# Altium schematic sheet MCU045A_eZFET_DCDC.SchDoc(MCU045A_eZFET_DCDC)
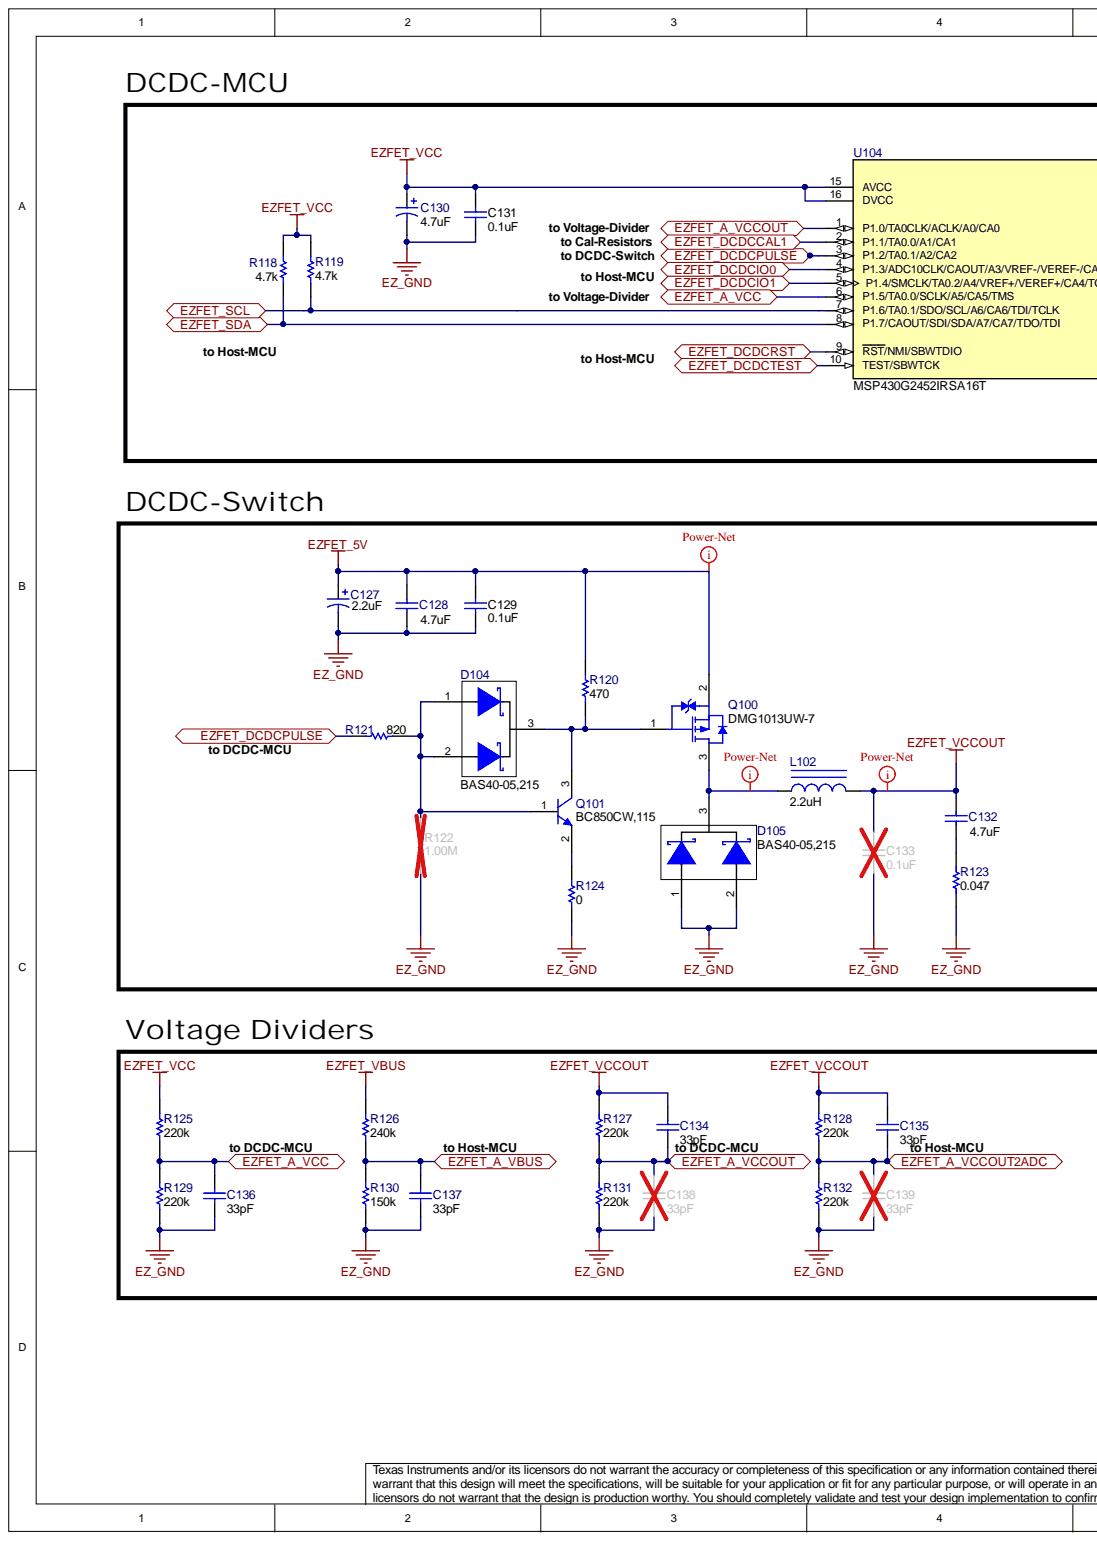
[diagram p0: MCU045A_eZFET_DCDC.SchDoc, left]
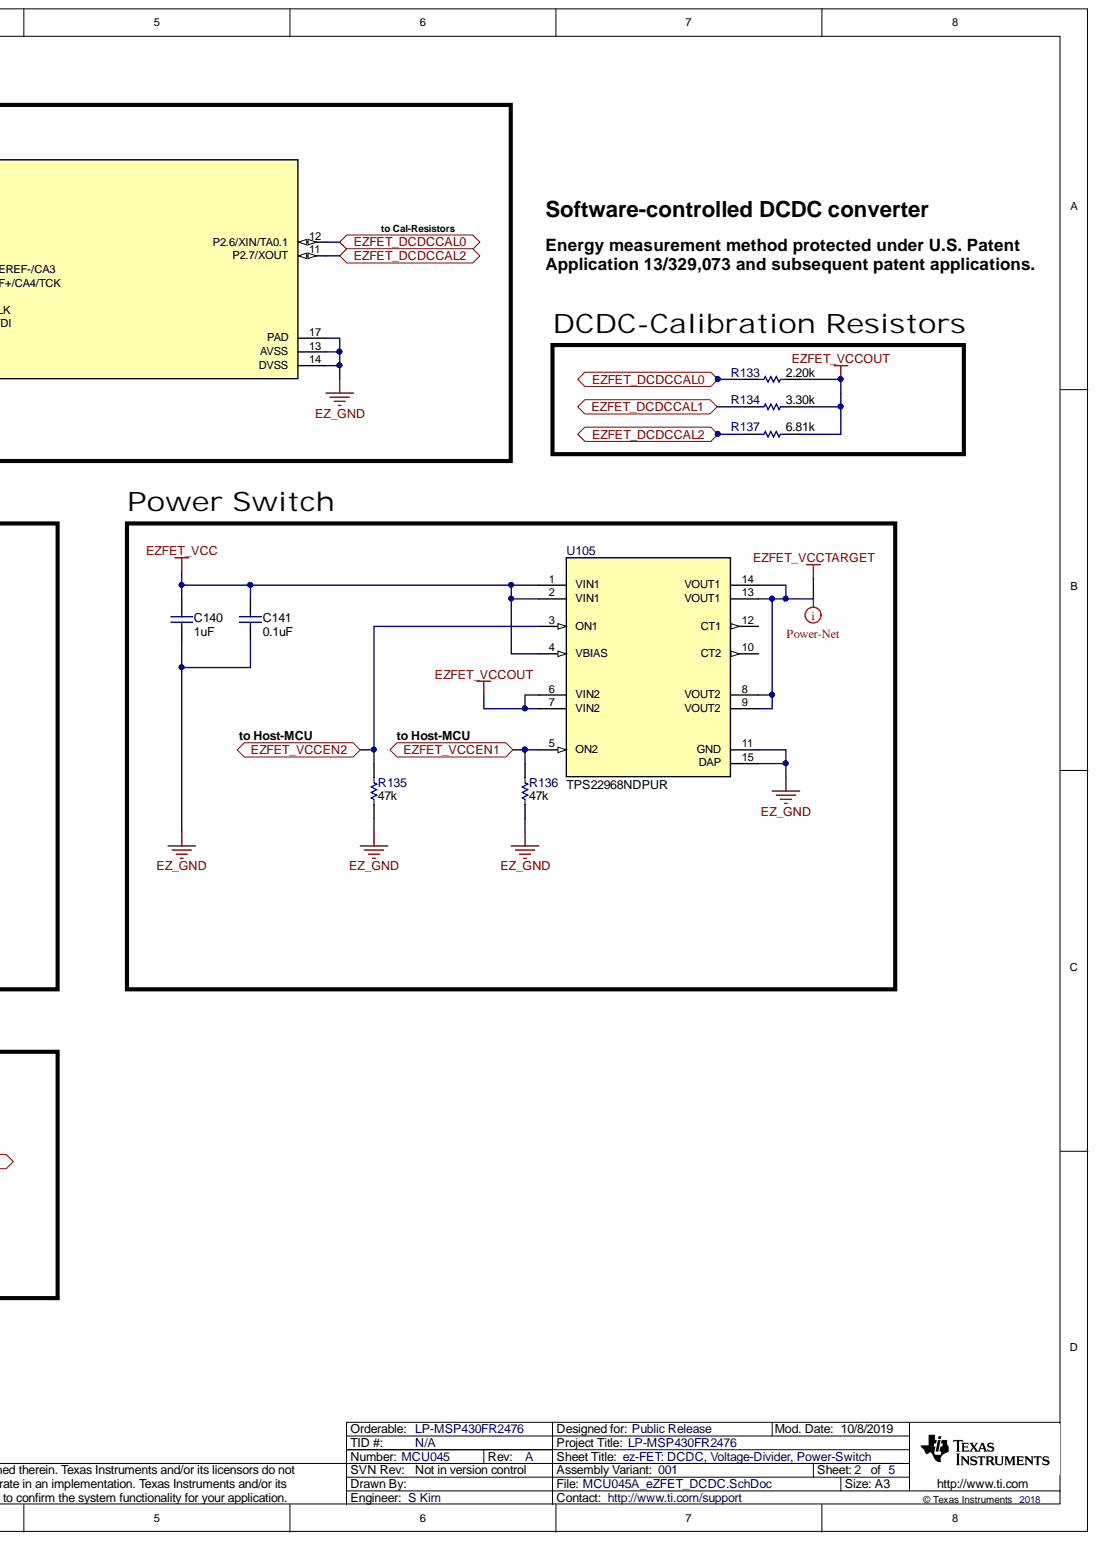
[diagram p1: MCU045A_eZFET_DCDC.SchDoc, right]

## Parts
C127  value 2.2uF  ValueDisplayed: 2.2uF  [3216-18]  (hidden comment: 293D225X9025A2TE3)
C128  value 4.7uF  ValueDisplayed: 4.7uF  [0805_HV]  (hidden comment: C0805C475K8PACTU)
C129  value 0.1uF  ValueDisplayed: 0.1uF  [0402]  (hidden comment: GCM155R71C104KA55D)
C130  value 4.7uF  ValueDisplayed: 4.7uF  [3216-18]  (hidden comment: 293D475X9010A2TE3)
C131  value 0.1uF  ValueDisplayed: 0.1uF  [0402]  (hidden comment: GCM155R71C104KA55D)
C132  value 4.7uF  ValueDisplayed: 4.7uF  [0603]  (hidden comment: CGB3B1X5R1A475K055AC)
C133  value 0.1uF  ValueDisplayed: 0.1uF  [0402]  (hidden comment: GMK105BJ104KV-F)
C134  value 33pF  ValueDisplayed: 33pF  [0402]  (hidden comment: 885012005043)
C135  value 33pF  ValueDisplayed: 33pF  [0402]  (hidden comment: 885012005043)
C136  value 33pF  ValueDisplayed: 33pF  [0402]  (hidden comment: 885012005043)
C137  value 33pF  ValueDisplayed: 33pF  [0402]  (hidden comment: 885012005043)
C138  value 33pF  ValueDisplayed: 33pF  [0402]  (hidden comment: 885012005043)
C139  value 33pF  ValueDisplayed: 33pF  [0402]  (hidden comment: 885012005043)
C140  value 1uF  ValueDisplayed: 1uF  [0402]  (hidden comment: GRM152R60J105ME15D)
C141  value 0.1uF  ValueDisplayed: 0.1uF  [0402]  (hidden comment: GCM155R71C104KA55D)
D104  BAS40-05,215  [SOT-23]
D105  BAS40-05,215  [SOT-23]
L102  value 2.2uH  ValueDisplayed: 2.2uH  [CBC2518]  (hidden comment: CBC2518T2R2M)
Q100  DMG1013UW-7  [SOT-323]
Q101  BC850CW,115  AEC Category: Q101  [SOT-323]
R118  value 4.7k  ValueDisplayed: 4.7k  [0402]  (hidden comment: CRCW04024K70JNED)
R119  value 4.7k  ValueDisplayed: 4.7k  [0402]  (hidden comment: CRCW04024K70JNED)
R120  value 470  [0402]  (hidden comment: RC0402FR-07470RL)
R121  value 820  [0402]  (hidden comment: RC0402FR-07820RL)
R122  ValueDisplayed: 1.00M  [0402]  (hidden comment: CRCW04021M00FKED)
R123  value 0.047  ValueDisplayed: 0.047  [0603]  (hidden comment: ERJ-L03KF47MV)
R124  value 0  [0402]  (hidden comment: RC0402JR-070RL)
R125  value 220k  ValueDisplayed: 220k  [0402]  (hidden comment: RC0402FR-07220KL)
R126  value 240k  ValueDisplayed: 240k  [0402]  (hidden comment: CRCW0402240KFKED)
R127  value 220k  ValueDisplayed: 220k  [0603]  (hidden comment: CPF0603B220KE)
R128  value 220k  ValueDisplayed: 220k  [0603]  (hidden comment: CPF0603B220KE)
R129  value 220k  ValueDisplayed: 220k  [0402]  (hidden comment: RC0402FR-07220KL)
R130  value 150k  ValueDisplayed: 150k  [0402]  (hidden comment: CRCW0402150KFKED)
R131  value 220k  ValueDisplayed: 220k  [0603]  (hidden comment: CPF0603B220KE)
R132  value 220k  ValueDisplayed: 220k  [0603]  (hidden comment: CPF0603B220KE)
R133  value 2.20k  ValueDisplayed: 2.20k  [0402]  (hidden comment: ERA2AEB222X)
R134  value 3.30k  ValueDisplayed: 3.30k  [0402]  (hidden comment: ERA2ARB332X)
R135  value 47k  ValueDisplayed: 47k  [0402]  (hidden comment: CRCW040247K0JNED)
R136  value 47k  ValueDisplayed: 47k  [0402]  (hidden comment: CRCW040247K0JNED)
R137  value 6.81k  ValueDisplayed: 6.81k  [0402]  (hidden comment: ERA2AEB6811X)
U104  MSP430G2452IRSA16T  [RSA0016B]
U105  TPS22968NDPUR  [DPU0014A]

## Ports
EZFET_A_VBUS, EZFET_A_VCC, EZFET_A_VCCOUT, EZFET_A_VCCOUT2ADC, EZFET_DCDCCAL0, EZFET_DCDCCAL1, EZFET_DCDCCAL2, EZFET_DCDCIO0, EZFET_DCDCIO1, EZFET_DCDCPULSE, EZFET_DCDCRST, EZFET_DCDCTEST, EZFET_SCL, EZFET_SDA, EZFET_VCCEN1, EZFET_VCCEN2

## Nets
EZ_GND: C127.2 C128.2 C129.2 C130.2 C131.2 C133.2 C136.2 C137.2 C138.2 C139.2 C140.2 C141.1 D105.1 D105.2 R122.1 R123.1 R124.1 R129.1 R130.1 R131.1 R132.1 R135.1 R136.1 U104.13(AVSS) U104.14(DVSS) U104.17(PAD) U105.11(GND) U105.15(DAP)
EZFET_5V: C127.1 C128.1 C129.1 Q100.2 R120.2
EZFET_VBUS: R126.2
EZFET_VCC: C130.1 C131.1 C140.1 C141.2 R118.2 R119.2 R125.2 U104.15(AVCC) U104.16(DVCC) U105.1(VIN1) U105.2(VIN1) U105.4(VBIAS)
EZFET_VCCOUT: C132.1 C133.1 C134.1 C135.1 L102.2 R127.2 R128.2 R133.2 R134.2 R137.2 U105.6(VIN2) U105.7(VIN2)
EZFET_VCCTARGET: U105.8(VOUT2) U105.9(VOUT2) U105.13(VOUT1) U105.14(VOUT1)
EZFET_A_VBUS: C137.1 R126.1 R130.2 ; port EZFET_A_VBUS
EZFET_A_VCC: C136.1 R125.1 R129.2 U104.6(P1.5/TA0.0/SCLK/A5/CA5/TMS) ; port EZFET_A_VCC
EZFET_A_VCCOUT: C134.2 C138.1 R127.1 R131.2 U104.1(P1.0/TA0CLK/ACLK/A0/CA0) ; port EZFET_A_VCCOUT
EZFET_A_VCCOUT2ADC: C135.2 C139.1 R128.1 R132.2 ; port EZFET_A_VCCOUT2ADC
EZFET_DCDCCAL0: R133.1 U104.12(P2.6/XIN/TA0.1) ; port EZFET_DCDCCAL0
EZFET_DCDCCAL1: R134.1 U104.2(P1.1/TA0.0/A1/CA1) ; port EZFET_DCDCCAL1
EZFET_DCDCCAL2: R137.1 U104.11(P2.7/XOUT) ; port EZFET_DCDCCAL2
EZFET_DCDCIO0: U104.4(P1.3/ADC10CLK/CAOUT/A3/VREF-/VEREF-/CA3) ; port EZFET_DCDCIO0
EZFET_DCDCIO1: U104.5(P1.4/SMCLK/TA0.2/A4/VREF+/VEREF+/CA4/TCK) ; port EZFET_DCDCIO1
EZFET_DCDCPULSE: R121.1 U104.3(P1.2/TA0.1/A2/CA2) ; port EZFET_DCDCPULSE
EZFET_DCDCRST: U104.9(RST/NMI/SBWTDIO) ; port EZFET_DCDCRST
EZFET_DCDCTEST: U104.10(TEST/SBWTCK) ; port EZFET_DCDCTEST
EZFET_SCL: R119.1 U104.7(P1.6/TA0.1/SDO/SCL/A6/CA6/TDI/TCLK) ; port EZFET_SCL
EZFET_SDA: R118.1 U104.8(P1.7/CAOUT/SDI/SDA/A7/CA7/TDO/TDI) ; port EZFET_SDA
EZFET_VCCEN1: R136.2 U105.5(ON2) ; port EZFET_VCCEN1
EZFET_VCCEN2: R135.2 U105.3(ON1) ; port EZFET_VCCEN2
NetC132_2: C132.2 R123.2
NetD104_1: D104.1 D104.2 Q101.1 R121.2 R122.2
NetD104_3: D104.3 Q100.1 Q101.3 R120.1
NetD105_3: D105.3 L102.1 Q100.3
NetQ101_2: Q101.2 R124.2

Not connected: U105 10(CT2) 12(CT1)

## Library data of the parts
C127 = CMP-0011454-2: CAP, TA, 2.2 uF, 25 V, +/- 10%, 6.3 ohm, SMD; Manufacturer: Vishay-Sprague; Value2: 25V; Value1: 6.3 ohm; U/M: EA; TaMin_C: -55°C; TaMax_C: 125°C; SizeZ_mm: 1.8; SizeY_mm: 1.6; SizeX_mm: 3.2; SizeAreaWB_mm2: 10.92; SizeArea_mm2: 5.12; Polarized: Y; IRMS_A: 1.1dA; ESR_ohm: 6.3; CompType: Capacitor; Cap_F: 2.2µF; Cap_Case: A; AEC Category: Q200; Tolerance_%: 10%; Technology: TA; Supplier Part Number 3: 74-293D225X9025A2TE3; Supplier Part Number 2: 09K6176; Supplier Part Number 1: 718-1134-1-ND; Supplier 3: Mouser; Supplier 2: Newark; Supplier 1: Digi-Key; Series: 293D; RoHS: Y; PartNumber: 293D225X9025A2TE3; PackageReference: 3216-18; MountType: SMT; Library Name: Altium Vault - TI; LatestRevisionNote: Updated supplier links; LatestRevisionDate: 2017-03-09; ComponentLink1URL: http://www.vishay.com/docs/40002/293d.pdf; ComponentLink1Description: Datasheet link; Component Kind: Standard; AEC Qualified: ?; Publisher: Texas Instruments
C128 = CMP-0007801-2: CAP, CERM, 4.7 uF, 10 V, +/- 10%, X5R, 0805; Manufacturer: Kemet; VDC_V: 10V; Value1: 0.004 ohm; U/M: EA; TaMin_C: -55°C; TaMax_C: 85°C; SizeZ_mm: 1.45; SizeY_mm: 1.25; SizeX_mm: 2; SizeAreaWB_mm2: 6.75; SizeArea_mm2: 2.5; Polarized: N; IRMS_A: 9.89A; ESR_ohm: 4m; CompType: Capacitor; Cap_F: 4.7µF; Cap_Case: 0805; AEC Category: Q200; Value2: 10V; Tolerance_%: 10%; TempCo: X5R; Technology: Ceramic; Supplier Part Number 3: 80-C0805C475K8P; Supplier Part Number 2: 70K9144; Supplier Part Number 1: 399-3133-1-ND; Supplier 3: Mouser; Supplier 2: Newark; Supplier 1: Digi-Key; RoHS: Y; Published: 2008-06-19; PartNumber: C0805C475K8PACTU; PackageReference: 0805; MountType: SMT; Library Name: Altium Vault - TI; LatestRevisionDate: 2017-03-09; ComponentLink1URL: http://www.kemet.com/kemet/web/homepage/kechome.nsf/file/Partial%20Copy%20-%20Ceramic%20Surface%20Mount%20Capacitors/$file/F3102_CE.pdf; ComponentLink1Description: Datasheet link; Component Kind: Standard; AEC Qualified: ?; Publisher: Texas Instruments
C129 C131 C141 = CMP-0006351-2: CAP, CERM, 0.1 uF, 16 V, +/- 10%, X7R, 0402; Manufacturer: MuRata; VDC_V: 16V; Value1: Unknown; U/M: EA; TaMin_C: -55°C; TaMax_C: 125°C; SizeZ_mm: 0.6; SizeY_mm: 0.5; SizeX_mm: 1; SizeAreaWB_mm2: 3; Polarized: N; CompType: Capacitor; Cap_F: 100nF; AEC Category: Q200; Value2: 16V; Tolerance_%: 10%; TempCo: X7R; Technology: Ceramic; Supplier Part Number 1: 490-4759-2-ND; Supplier 1: Digi-Key; SizeArea_mm2: 0.5; RoHS: Y; Published: 2008-06-19; PartNumber: GCM155R71C104KA55D; PackageReference: 0402; MountType: SMT; Library Name: Altium Vault - TI; LatestRevisionDate: 2017-03-09; ComponentLink1URL: http://psearch.murata.com/capacitor/product/GCM155R71C104KA55%23.pdf; ComponentLink1Description: Datasheet link; Component Kind: Standard; Cap_Case: 0402; AEC Qualified: ?; Publisher: Texas Instruments
C130 = CMP-0011459-2: CAP, TA, 4.7 uF, 10 V, +/- 10%, 5 ohm, SMD; Manufacturer: Vishay-Sprague; VDC_V: 10V; Value1: 5 ohm; U/M: EA; TaMin_C: -55°C; TaMax_C: 125°C; SizeZ_mm: 1.8; SizeY_mm: 1.6; SizeX_mm: 3.2; SizeAreaWB_mm2: 10.92; SizeArea_mm2: 5.12; Polarized: Y; IRMS_A: 1.2dA; ESR_ohm: 5; CompType: Capacitor; Cap_F: 4.7µF; Cap_Case: A; AEC Category: Q200; Value2: 10V; Tolerance_%: 10%; Technology: TA; Supplier Part Number 3: 197397; Supplier Part Number 2: 74-293D475X9010A2TE3; Supplier Part Number 1: 718-1146-1-ND; Supplier 3: Farnell; Supplier 2: Mouser; Supplier 1: Digi-Key; Series: 293D; RoHS: Y; PartNumber: 293D475X9010A2TE3; PackageReference: 3216-18; MountType: SMT; Library Name: Altium Vault - TI; LatestRevisionNote: Updated supplier links; LatestRevisionDate: 2017-03-09; ComponentLink1URL: http://www.vishay.com/docs/40002/293d.pdf; ComponentLink1Description: Datasheet link; Component Kind: Standard; AEC Qualified: ?; Publisher: Texas Instruments
C132 = CMP-0007105-5: CAP, CERM, 4.7 uF, 10 V, +/- 10%, X5R, 0603; Manufacturer: TDK; VDC_V: 10V; Value1: Unknown; U/M: EA; TaMin_C: -55°C; TaMax_C: 85°C; SizeZ_mm: 1; SizeY_mm: 0.8; SizeX_mm: 1.6; SizeAreaWB_mm2: 4.68; SizeArea_mm2: 1.28; Polarized: N; CompType: Capacitor; Cap_F: 4.7µF; AEC Category: Q200; Value2: 10V; Tolerance_%: 10%; TempCo: X5R; Technology: Ceramic; Supplier Part Number 1: 445-7482-1-ND; Supplier 1: Digi-Key; RoHS: Y; Published: 2008-06-19; PartNumber: CGB3B1X5R1A475K055AC; PackageReference: 0603; MountType: SMT; Library Name: Altium Vault - TI; LatestRevisionDate: 2017-03-09; ComponentLink1URL: http://www.tdk.com/pdf/mlcc_product_guide_A12.pdf; ComponentLink1Description: Datasheet link; Component Kind: Standard; Cap_Case: 0603; AEC Qualified: ?; Publisher: Texas Instruments
C133 = CMP-0006370-2: CAP, CERM, 0.1 uF, 35 V, +/- 10%, X5R, 0402; Manufacturer: Taiyo Yuden; VDC_V: 35V; Value1: Unknown; U/M: EA; TaMin_C: -55°C; TaMax_C: 85°C; SizeZ_mm: 0.6; SizeY_mm: 0.5; SizeX_mm: 1; SizeAreaWB_mm2: 3; Polarized: N; CompType: Capacitor; Cap_F: 100nF; AEC Category: Q200; Value2: 35V; Tolerance_%: 10%; TempCo: X5R; Technology: Ceramic; Supplier Part Number 3: 963-GMK105BJ104KV-F; Supplier Part Number 2: 84R9117; Supplier Part Number 1: 587-1994-1-ND; Supplier 3: Mouser; Supplier 2: Newark; Supplier 1: Digi-Key; SizeArea_mm2: 0.5; RoHS: Y; Published: 2008-06-19; PartNumber: GMK105BJ104KV-F; PackageReference: 0402; MountType: SMT; Library Name: Altium Vault - TI; LatestRevisionDate: 2017-03-09; ComponentLink1URL: http://www.yuden.co.jp/productdata/catalog/en/mlcc_all_e.pdf; ComponentLink1Description: Datasheet link; Component Kind: Standard; Cap_Case: 0402; AEC Qualified: N; AEC Grade: N/A; Publisher: Texas Instruments
C134-C139 = CMP-0006213-2: CAP, CERM, 33 pF, 25 V, +/- 5%, C0G/NP0, 0402; Manufacturer: Wurth Elektronik; VDC_V: 25V; Value1: Unknown; U/M: EA; TaMin_C: -55°C; TaMax_C: 125°C; SizeZ_mm: 0.6; SizeY_mm: 0.5; SizeX_mm: 1; SizeAreaWB_mm2: 3; Polarized: N; CompType: Capacitor; AEC Category: Q200; Value2: 25V; Tolerance_%: 5%; TempCo: C0G/NP0; Technology: Ceramic; Supplier Part Number 1: 710-885012005043; Supplier 1: Mouser; SizeArea_mm2: 0.5; RoHS: Y; Published: 2008-06-19; PartNumber: 885012005043; PackageReference: 0402; MountType: SMT; Library Name: Altium Vault - TI; LatestRevisionDate: 2017-03-09; ComponentLink1URL: http://katalog.we-online.de/pbs/datasheet/885012005043.pdf; ComponentLink1Description: Datasheet link; Component Kind: Standard; Cap_F: 33pF; Cap_Case: 0402; AEC Qualified: N; AEC Grade: N/A; Publisher: Texas Instruments
C140 = CMP-0006372-2: CAP, CERM, 1 uF, 6.3 V, +/- 20%, X5R, 0402; Manufacturer: MuRata; VDC_V: 6.3V; Value1: Unknown; U/M: EA; TaMin_C: -55°C; TaMax_C: 85°C; SizeZ_mm: 0.6; SizeY_mm: 0.5; SizeX_mm: 1; SizeAreaWB_mm2: 3; Polarized: N; CompType: Capacitor; Cap_F: 1µF; AEC Category: Q200; Value2: 6.3V; Tolerance_%: 20%; TempCo: X5R; Technology: Ceramic; Supplier Part Number 2: 81-GRM152R60J105ME5D; Supplier Part Number 1: 490-10006-1-ND; Supplier 2: Mouser; Supplier 1: Digi-Key; SizeArea_mm2: 0.5; RoHS: Y; Published: 2008-06-19; PartNumber: GRM152R60J105ME15D; PackageReference: 0402; MountType: SMT; Library Name: Altium Vault - TI; LatestRevisionDate: 2017-03-09; ComponentLink1URL: http://psearch.murata.com/capacitor/product/GRM152R60J105ME15%23.pdf; ComponentLink1Description: Datasheet link; Component Kind: Standard; Cap_Case: 0402; AEC Qualified: ?; Publisher: Texas Instruments
D104 D105 = CMP-0074700-1: Diode, Schottky, 40 V, 0.12 A, AEC-Q101, SOT-23; Manufacturer: Nexperia; Vr_V: 40V; Vf_V: 3.8dV; Value2: 0.38V; Value1: 0.12A; Value: 40V; U/M: EA; TJmax_C: 150°C; SizeZ_mm: 1.1; SizeY_mm: 3.0; SizeX_mm: 2.5; SizeAreaWB_mm2: 14.0; SizeArea_mm2: 7.5; Io_A: 1.2dA; AEC Qualified: Y; AEC Category: Q101; Type: Schottky; Supplier Part Number 3: 771-BAS40-05-T/R; Supplier Part Number 2: 75R4943; Supplier Part Number 1: 1727-4235-1-ND; Supplier 3: Mouser; Supplier 2: Newark; Supplier 1: Digi-Key; RoHS: Y; Published: 2008-06-26; PartNumber: BAS40-05,215; PackageReference: SOT-23; MountType: SMT; Library Name: Altium Vault - TI; LatestRevisionDate: 2017-06-26; CompType: Diode; ComponentLink1URL: https://assets.nexperia.com/documents/data-sheet/BAS40_1PSXXSB4X_SER.pdf; ComponentLink1Description: Datasheet link; Component Kind: Standard; Publisher: Texas Instruments
L102 = CMP-0059590-1: Inductor, Wirewound, Ceramic, 2.2 uH, 0.89 A, 0.13 ohm, SMD; Manufacturer: Taiyo Yuden; Value2: 0.89A; SizeZ_mm: 1.8; SizeX_mm: 2.5; SizeAreaWB_mm2: 9.8; SizeArea_mm2: 4.5; Isat: 0.89A @ 30% inductance drop; Irms: 1.1A @ 40C rise; Ind_H: 2.2µH; Imax_A: 8.9dA; Green_REACh: Passed; Green_Lifecycle: Active; DCR_ohm: 1.3d; AEC Qualified: N; AEC Category: Q200; Value1: 0.13 ohm; Technology: Wirewound; Supplier Part Number 3: 963-CBC2518T2R2M; Supplier Part Number 2: 18J0834; Supplier Part Number 1: 587-1618-1-ND; Supplier 3: Mouser; Supplier 2: Newark; Supplier 1: Digi-Key; SizeY_mm: 1.8; RoHS: Y; Published: 2008-06-26; PartNumber: CBC2518T2R2M; PackageReference: 2.5x1.8x1.8mm; MountType: SMT; Material: Ceramic; Library Name: Altium Vault - TI; LatestRevisionDate: 2017-06-02; Green_EU RoHS-2: Passed; Green_EU RoHS: Passed; CompType: Inductor; ComponentLink1URL: http://www.yuden.co.jp/productdata/catalog/en/wound02_e.pdf; ComponentLink1Description: Datasheet link; Component Kind: Standard; AEC Grade: N/A; Publisher: Texas Instruments
Q100 = CMP-0000035-2: MOSFET, P-CH, -20 V, -0.82 A, SOT-323; Manufacturer: Diodes Inc.; Alternate Manufacturer: None; Vgs_V: -6V; VdsMax_V: -20V; Value2: 0.622 nC; Value1: 0.7; Value: -20V; U/M: EA; SizeZ_mm: 1.1; SizeY_mm: 2.2; SizeAreaWB_mm2: 10.24; SizeArea_mm2: 4.84; Rdson45_ohm: 7.5d; QgTyp_nC: 0.622; IdsMax_A: -8.2dA; ChType: P; AEC Category: Q101; Supplier Part Number 2: 621-DMG1013UW-7; Supplier Part Number 1: DMG1013UW-7DICT-ND; Supplier 2: Mouser; Supplier 1: Digi-Key; SizeX_mm: 2.2; RoHS: Y; Published: 2008-06-19; PartNumber: DMG1013UW-7; PackageReference: SOT-323; MountType: SMT; Library Name: Altium Vault - TI; LatestRevisionNote: Added parts.; LatestRevisionDate: 2017-03-09; CompType: MOSFET; ComponentLink1URL: http://www.diodes.com/datasheets/ds31861.pdf; ComponentLink1Description: Datasheet link; Component Kind: Standard; AEC Qualified: ?; Publisher: Texas Instruments
Q101 = CMP-0000466-2: Transistor, NPN, 45 V, 0.1 A, SOT-323; Manufacturer: NXP Semiconductor; Vcesat1_V: 2.5dV; Vceo_V: 45V; Value2: 800; Value1: 0.1 A; Value: 45 V; U/M: EA; TaMin_C: -65°C; TaMax_C: 150°C; SizeZ_mm: 1.1; SizeY_mm: 2.2; SizeAreaWB_mm2: 10.24; SizeArea_mm2: 4.84; PNP: 0; NPN: 1; IcRated_A: 1dA; CompType: BJT; Supplier Part Number 3: 771-BC850CW-T/R; Supplier Part Number 2: 75R0746; Supplier Part Number 1: 568-6076-1-ND; Supplier 3: Mouser; Supplier 2: Newark; Supplier 1: Digi-Key; SizeX_mm: 2.2; RoHS: Y; Published: 2008-07-31; PartNumber: BC850CW,115; PackageReference: SOT-323; MountType: SMT; Library Name: Altium Vault - TI; LatestRevisionDate: 2017-03-09; HfeMax: 800; ComponentLink1URL: http://www.nxp.com/documents/data_sheet/BC849W_850W.pdf; ComponentLink1Description: Datasheet link; Component Kind: Standard; AEC Qualified: ?; Publisher: Texas Instruments
R118 R119 = CMP-0026938-5: RES, 4.7 k, 5%, 0.063 W, AEC-Q200 Grade 0, 0402; Manufacturer: Vishay-Dale; VDC_V: 50V; Value_Code: 4k70; Value2: 0.063W; TempCo: 200 ppm/C; SizeZ_mm: 0.6; SizeY_mm: 0.5; SizeX_mm: 1; Power_W: 63mW; EIA_Code: E24; CompType: Resistor; AEC Qualified: Y; AEC Grade: 0; AEC Category: Q200; Value1: 5%; Tolerance_%: 5%; Technology: Thick Film; Supplier Part Number 3: 71-CRCW0402J-4.7K-E3; Supplier Part Number 2: 59M6733; Supplier Part Number 1: 541-4.7KJCT-ND; Supplier 3: Mouser; Supplier 2: Newark; Supplier 1: Digi-Key; SizeArea_mm2: 0.5; RoHS: E; Res_ohm: 4.7k; PartNumber: CRCW04024K70JNED; PackageReference: 0402; MountType: SMT; Library Name: Altium Vault - TI; ComponentLink1URL: http://www.vishay.com/docs/20035/dcrcwe3.pdf; ComponentLink1Description: Datasheet link; Component Kind: Standard
R120 = CMP-0026736-1: RES, 470, 1%, 0.063 W, 0402; Manufacturer: Yageo America; VDC_V: 50V; Value_Code: 470R; Value2: 0.063W; U/M: EA; TempCo: 100 ppm/C; SizeZ_mm: 0.6; SizeY_mm: 0.5; SizeX_mm: 1; Power_W: 63mW; CompType: Resistor; AEC Qualified: N; AEC Category: Q200; ValueDisplayed: 470; Value1: 1%; Tolerance_%: 1%; Technology: Thick Film; Supplier Part Number 3: 603-RC0402FR-07470RL; Supplier Part Number 2: 67R9940; Supplier Part Number 1: 311-470LRCT-ND; Supplier 3: Mouser; Supplier 2: Newark; Supplier 1: Digi-Key; SizeArea_mm2: 0.5; RoHS: Y; Res_ohm: 470; Published: 2008-06-26; PartNumber: RC0402FR-07470RL; PackageReference: 0402; MountType: SMT; Library Name: Altium Vault - TI; LatestRevisionDate: 2017-03-09; ComponentLink1URL: http://www.yageo.com/documents/recent/PYu-RC_Group_51_RoHS_L_04.pdf; ComponentLink1Description: Datasheet link; Component Kind: Standard; AEC Grade: N/A; Publisher: Texas Instruments
R121 = CMP-0026743-1: RES, 820, 1%, 0.063 W, 0402; Manufacturer: Yageo America; VDC_V: 50V; Value_Code: 820R; Value2: 0.063W; U/M: EA; TempCo: 100 ppm/C; SizeZ_mm: 0.6; SizeY_mm: 0.5; SizeX_mm: 1; Power_W: 63mW; CompType: Resistor; AEC Qualified: N; AEC Category: Q200; ValueDisplayed: 820; Value1: 1%; Tolerance_%: 1%; Technology: Thick Film; Supplier Part Number 3: 603-RC0402FR-07820RL; Supplier Part Number 2: 26M6794; Supplier Part Number 1: 311-820LRCT-ND; Supplier 3: Mouser; Supplier 2: Newark; Supplier 1: Digi-Key; SizeArea_mm2: 0.5; RoHS: Y; Res_ohm: 820; Published: 2008-06-26; PartNumber: RC0402FR-07820RL; PackageReference: 0402; MountType: SMT; Library Name: Altium Vault - TI; LatestRevisionDate: 2017-03-09; ComponentLink1URL: http://www.yageo.com/documents/recent/PYu-RC_Group_51_RoHS_L_04.pdf; ComponentLink1Description: Datasheet link; Component Kind: Standard; AEC Grade: N/A; Publisher: Texas Instruments
R122 = CMP-0026100-2: RES, 1.00 M, 1%, 0.063 W, AEC-Q200 Grade 0, 0402; Manufacturer: Vishay-Dale; VDC_V: 50V; Value_Code: 1M00; Value2: 0.063W; Value: 1.00Meg; TempCo: 100 ppm/C; SizeZ_mm: 0.6; SizeY_mm: 0.5; SizeX_mm: 1; Res_ohm: 1M; Power_W: 63mW; EIA_Code: E96; CompType: Resistor; AEC Qualified: Y; AEC Grade: 0; AEC Category: Q200; Value1: 1%; Tolerance_%: 1%; Technology: Thick Film; Supplier Part Number 3: 71-CRCW0402-1.0M-E3; Supplier Part Number 2: 52K6593; Supplier Part Number 1: 541-1.00MLCT-ND; Supplier 3: Mouser; Supplier 2: Newark; Supplier 1: Digi-Key; SizeArea_mm2: 0.5; RoHS: E; PartNumber: CRCW04021M00FKED; PackageReference: 0402; MountType: SMT; Library Name: Altium Vault - TI; ComponentLink1URL: http://www.vishay.com/docs/20035/dcrcwe3.pdf; ComponentLink1Description: Datasheet link; Component Kind: Standard
R123 = CMP-0022726-3: RES, 0.047, 1%, 0.1 W, AEC-Q200 Grade 1, 0603; Manufacturer: Panasonic; Value_Code: R047; Value2: 0.1W; TempCo: 200 ppm/C; SizeZ_mm: 1; SizeY_mm: 0.8; SizeX_mm: 1.6; SizeAreaWB_mm2: 4.68; SizeArea_mm2: 1.28; Power_W: 100mW; EIA_Code: E96; CompType: Resistor; AEC Qualified: Y; AEC Category: Q200; Value1: 1%; Tolerance_%: 1%; Technology: Thick Film; Supplier Part Number 3: 1577548; Supplier Part Number 2: 667-ERJ-L03KF47MV; Supplier Part Number 1: P47LJCT-ND; Supplier 3: Farnell; Supplier 2: Mouser; Supplier 1: Digi-Key; RoHS: Y; Res_ohm: 0.047; PartNumber: ERJ-L03KF47MV; PackageReference: 0603; MountType: SMT; Library Name: Altium Vault - TI; ComponentLink1URL: https://industrial.panasonic.com/cdbs/www-data/pdf/RDN0000/AOA0000C313.pdf; ComponentLink1Description: Datasheet link; Component Kind: Standard; AEC Grade: 1
R124 = CMP-0027004-2: RES, 0, 5%, 0.063 W, 0402; Manufacturer: Yageo America; VDC_V: 50V; Value_Code: 0000; Value2: 0.063W; U/M: EA; TempCo: 200 ppm/C; SizeZ_mm: 0.6; SizeY_mm: 0.5; SizeX_mm: 1; Power_W: 63mW; EIA_Code: E24; CompType: Resistor; AEC Category: Q200; ValueDisplayed: 0; Value1: 5%; Tolerance_%: 5%; Technology: Thick Film; Supplier Part Number 3: 9232516; Supplier Part Number 2: 603-RC0402JR-070RL; Supplier Part Number 1: 311-0.0JRCT-ND; Supplier 3: Farnell; Supplier 2: Mouser; Supplier 1: Digi-Key; SizeArea_mm2: 0.5; RoHS: Y; Res_ohm: 0; Published: 2008-06-26; PartNumber: RC0402JR-070RL; PackageReference: 0402; MountType: SMT; Library Name: Altium Vault - TI; LatestRevisionDate: 2017-03-09; ComponentLink1URL: http://www.yageo.com/pdf/Pu-RC0402_51_PbFree_L_2.pdf; ComponentLink1Description: Datasheet link; Component Kind: Standard; AEC Qualified: ?; Publisher: Texas Instruments
R125 R129 = CMP-0026728-1: RES, 220 k, 1%, 0.0625 W, 0402; Manufacturer: Yageo America; VDC_V: 50V; U/M: EA; TempCo: 100 ppm/C; SizeZ_mm: 0.6; SizeY_mm: 0.5; SizeX_mm: 1; Power_W: 62.5mW; CompType: Resistor; AEC Qualified: N; AEC Category: Q200; Value_Code: 220k; Value2: 0.0625W; Value1: 1%; Tolerance_%: 1%; Technology: Thick Film; Supplier Part Number 3: 603-RC0402FR-07220KL; Supplier Part Number 2: 98K7527; Supplier Part Number 1: 311-220KLRCT-ND; Supplier 3: Mouser; Supplier 2: Newark; Supplier 1: Digi-Key; SizeArea_mm2: 0.5; RoHS: Y; Res_ohm: 220k; Published: 2008-06-26; PartNumber: RC0402FR-07220KL; PackageReference: 0402; MountType: SMT; Library Name: Altium Vault - TI; LatestRevisionDate: 2017-03-09; ComponentLink1URL: http://www.yageo.com/documents/recent/PYu-RC0402_51_RoHS_L_6_r.pdf; ComponentLink1Description: Datasheet link; Component Kind: Standard; AEC Grade: N/A; Publisher: Texas Instruments
R126 = CMP-0026190-2: RES, 240 k, 1%, 0.063 W, AEC-Q200 Grade 0, 0402; Manufacturer: Vishay-Dale; VDC_V: 50V; Value2: 0.063W; TempCo: 100 ppm/C; SizeZ_mm: 0.6; SizeY_mm: 0.5; SizeX_mm: 1; Power_W: 63mW; CompType: Resistor; AEC Qualified: Y; AEC Grade: 0; AEC Category: Q200; Value_Code: 240k; Value1: 1%; Tolerance_%: 1%; Technology: Thick Film; Supplier Part Number 3: 71-CRCW0402240KFKED; Supplier Part Number 2: 51P0040; Supplier Part Number 1: 541-240KLCT-ND; Supplier 3: Mouser; Supplier 2: Newark; Supplier 1: Digi-Key; SizeArea_mm2: 0.5; RoHS: E; Res_ohm: 240k; PartNumber: CRCW0402240KFKED; PackageReference: 0402; MountType: SMT; Library Name: Altium Vault - TI; ComponentLink1URL: http://www.vishay.com/docs/20035/dcrcwe3.pdf; ComponentLink1Description: Datasheet link; Component Kind: Standard
R127 R128 R131 R132 = CMP-0023349-2: RES, 220 k, 0.1%, 0.063 W, 0603; Manufacturer: TE Connectivity; VDC_V: 50V; Value2: 0.063W; U/M: EA; TempCo: 25 ppm/C; SizeZ_mm: 1; SizeY_mm: 0.8; SizeX_mm: 1.6; SizeArea_mm2: 1.28; Power_W: 63mW; CompType: Resistor; AEC Qualified: N; AEC Category: Q200; Value_Code: 220k; Value1: 0.1%; Tolerance_%: 0.1%; Technology: Thin Film; Supplier Part Number 3: 279-CPF0603B220KE1; Supplier Part Number 2: 95W6624; Supplier Part Number 1: A103064CT-ND; Supplier 3: Mouser; Supplier 2: Newark; Supplier 1: Digi-Key; RoHS: Y; Res_ohm: 220k; Published: 2008-06-26; PartNumber: CPF0603B220KE; PackageReference: 0603; MountType: SMT; Library Name: Altium Vault - TI; LatestRevisionDate: 2017-03-09; ComponentLink1URL: http://www.te.com/commerce/DocumentDelivery/DDEController?Action=srchrtrv&DocNm=1773200&DocType=DS&DocLang=English; ComponentLink1Description: Datasheet link; Component Kind: Standard; AEC Grade: N/A; Publisher: Texas Instruments
R130 = CMP-0026021-3: RES, 150 k, 1%, 0.063 W, AEC-Q200 Grade 0, 0402; Manufacturer: Vishay-Dale; VDC_V: 50V; Value2: 0.063W; TempCo: 100 ppm/C; SizeZ_mm: 0.6; SizeY_mm: 0.5; SizeX_mm: 1; Power_W: 63mW; EIA_Code: E96; CompType: Resistor; AEC Qualified: Y; AEC Grade: 0; AEC Category: Q200; Value_Code: 150k; Value1: 1%; Tolerance_%: 1%; Technology: Thick Film; Supplier Part Number 3: 71-CRCW0402-150K-E3; Supplier Part Number 2: 52K6719; Supplier Part Number 1: 541-150KLCT-ND; Supplier 3: Mouser; Supplier 2: Newark; Supplier 1: Digi-Key; SizeArea_mm2: 0.5; RoHS: E; Res_ohm: 150k; PartNumber: CRCW0402150KFKED; PackageReference: 0402; MountType: SMT; Library Name: Altium Vault - TI; ComponentLink1URL: http://www.vishay.com/docs/20035/dcrcwe3.pdf; ComponentLink1Description: Datasheet link; Component Kind: Standard
R133 = CMP-0074667-1: RES, 2.20 k, 0.1%, 0.0625 W, AEC-Q200 Grade 0, 0402; Manufacturer: Panasonic; VDC_V: 50V; Value_Code: 2k20; U/M: EA; TempCo: 25 ppm/C; SizeZ_mm: 0.6; SizeY_mm: 0.5; SizeX_mm: 1.0; Res_ohm: 2.2k; Power_W: 62.5mW; CompType: Resistor; AEC Qualified: Y; AEC Grade: 0; AEC Category: Q200; Value2: 0.0625W; Value1: 0.1%; Tolerance_%: 0.1%; Technology: Thin Film; Supplier Part Number 3: 667-ERA-2AEB222X; Supplier Part Number 2: 05X8513; Supplier Part Number 1: P2.2KDCCT-ND; Supplier 3: Mouser; Supplier 2: Newark; Supplier 1: Digi-Key; SizeArea_mm2: 0.5; RoHS: Y; Published: 2008-06-26; PartNumber: ERA2AEB222X; PackageReference: 0402; MountType: SMT; Library Name: Altium Vault - TI; LatestRevisionDate: 2017-06-26; ComponentLink1URL: https://industrial.panasonic.com/cdbs/www-data/pdf/RDM0000/AOA0000C237.pdf; ComponentLink1Description: Datasheet link; Component Kind: Standard; Publisher: Texas Instruments
R134 = CMP-0074669-1: RES, 3.30 k, 0.1%, 0.0625 W, AEC-Q200 Grade 0, 0402; Manufacturer: Panasonic; VDC_V: 50V; Value_Code: 3k30; U/M: EA; TempCo: 25 ppm/C; SizeZ_mm: 0.6; SizeY_mm: 0.5; SizeX_mm: 1.0; Res_ohm: 3.3k; Power_W: 62.5mW; CompType: Resistor; AEC Qualified: Y; AEC Grade: 0; AEC Category: Q200; Value2: 0.0625W; Value1: 0.1%; Tolerance_%: 0.1%; Technology: Thin Film; Supplier Part Number 3: 667-ERA-2ARB332X; Supplier Part Number 2: 85Y5703; Supplier Part Number 1: P3.3KCPCT-ND; Supplier 3: Mouser; Supplier 2: Newark; Supplier 1: Digi-Key; SizeArea_mm2: 0.5; RoHS: Y; Published: 2008-06-26; PartNumber: ERA2ARB332X; PackageReference: 0402; MountType: SMT; Library Name: Altium Vault - TI; LatestRevisionDate: 2017-06-26; ComponentLink1URL: https://industrial.panasonic.com/cdbs/www-data/pdf/RDM0000/AOA0000C237.pdf; ComponentLink1Description: Datasheet link; Component Kind: Standard; Publisher: Texas Instruments
R135 R136 = CMP-0026935-3: RES, 47 k, 5%, 0.063 W, AEC-Q200 Grade 0, 0402; Manufacturer: Vishay-Dale; VDC_V: 50V; Value_Code: 47k0; Value2: 0.063W; TempCo: 200 ppm/C; SizeZ_mm: 0.6; SizeY_mm: 0.5; SizeX_mm: 1; Power_W: 63mW; EIA_Code: E24; CompType: Resistor; AEC Qualified: Y; AEC Grade: 0; AEC Category: Q200; Value1: 5%; Tolerance_%: 5%; Technology: Thick Film; Supplier Part Number 3: 71-CRCW0402-J-47K-E3; Supplier Part Number 2: 52K7099; Supplier Part Number 1: 541-47KJCT-ND; Supplier 3: Mouser; Supplier 2: Newark; Supplier 1: Digi-Key; SizeArea_mm2: 0.5; RoHS: E; Res_ohm: 47k; PartNumber: CRCW040247K0JNED; PackageReference: 0402; MountType: SMT; Library Name: Altium Vault - TI; ComponentLink1URL: http://www.vishay.com/docs/20035/dcrcwe3.pdf; ComponentLink1Description: Datasheet link; Component Kind: Standard
R137 = CMP-0074668-1: RES, 6.81 k, 0.1%, 0.0625 W, AEC-Q200 Grade 0, 0402; Manufacturer: Panasonic; VDC_V: 50V; Value_Code: 6k81; U/M: EA; TempCo: 25 ppm/C; SizeZ_mm: 0.6; SizeY_mm: 0.5; SizeX_mm: 1.0; Power_W: 62.5mW; EIA_Code: E192; CompType: Resistor; AEC Qualified: Y; AEC Grade: 0; AEC Category: Q200; Value2: 0.0625W; Value1: 0.1%; Tolerance_%: 0.1%; Technology: Thin Film; Supplier Part Number 3: 667-ERA-2AEB6811X; Supplier Part Number 2: 85Y5585; Supplier Part Number 1: P6.81KDCCT-ND; Supplier 3: Mouser; Supplier 2: Newark; Supplier 1: Digi-Key; SizeArea_mm2: 0.5; RoHS: Y; Res_ohm: 6.81k; Published: 2008-06-26; PartNumber: ERA2AEB6811X; PackageReference: 0402; MountType: SMT; Library Name: Altium Vault - TI; LatestRevisionDate: 2017-06-26; ComponentLink1URL: https://industrial.panasonic.com/cdbs/www-data/pdf/RDM0000/AOA0000C237.pdf; ComponentLink1Description: Datasheet link; Component Kind: Standard; Publisher: Texas Instruments
U104 = CMP-0039612-2: MSP430G2x52, MSP430G2x12 Mixed Signal Microcontroller, RSA0016B (VQFN-16); Manufacturer: Texas Instruments; Alternate PartNumber: MSP430G2452IRSA16R; Watchdog: Yes; Timers - 16-bit: 1; SRAM(B): 256; SizeY_mm: 4; SizeAreaWB_mm2: 25; SizeArea_mm2: 16; Rating: Catalog; Flash(KB): 8; Eco-Plan: Green (RoHS & no Sb/Br); CompType: IC; AEC Qualified: N; AEC Category: Q200; ADC: 10-bit SAR; Temp Sensor: Yes; SizeZ_mm: 1; SizeX_mm: 4; RoHS: Y; PartNumber: MSP430G2452IRSA16T; PackageReference: RSA0016B; PackageDescription: VQFN-16; Operating Temperature Range: -40 to 85 C; MountType: SMT; Library Name: Altium Vault - TI; GPIO: 16; Frequency(MHz): 16; DatasheetVersion: SLAS722E; ComponentLink3URL: http://www.ti.com/product/msp430g2452/datasheet; ComponentLink3Description: Online Datasheet; ComponentLink2URL: http://www.ti.com/product/msp430g2452; ComponentLink2Description: Product Folder; ComponentLink1URL: http://www.ti.com/lit/ds/symlink/msp430g2452.pdf; ComponentLink1Description: Datasheet Link; Component Kind: Standard; Comparators: Yes; Code_JEDEC: MO-220; Cap touch I/O: Yes; Brown Out Reset: Yes; ADC Channels: 8
U105 = CMP-0073681-2: 5.5V, 4A, 25mΩ, 2-Channel Load Switch With Quick Output Discharge and Adjustable Rise Time, DPU0014A (WSON-14); Manufacturer: Texas Instruments; U/M: EA; SizeZ_mm: 0.8; SizeY_mm: 3.0; SizeX_mm: 2.0; SizeAreaWB_mm2: 12.0; SizeArea_mm2: 6.0; Green_REACh: Passed; Green_Lifecycle: Active; CompType: IC; RoHS: Y; PartNumber: TPS22968NDPUR; PackageReference: DPU0014A; PackageDescription: WSON-14; MountType: SMT; Library Name: Altium Vault - TI; LatestRevisionDate: 2017-06-09; Green_EU RoHS-2: Passed; Green_EU RoHS: Passed; ComponentLink1URL: http://www.ti.com/lit/ds/symlink/tps22968.pdf; ComponentLink1Description: Datasheet Link; Component Kind: Standard; Alternate Manufacturer: Texas Instruments; Publisher: Texas Instruments
